# Altium schematic sheet peripherals.SchDoc(U_peripherals)
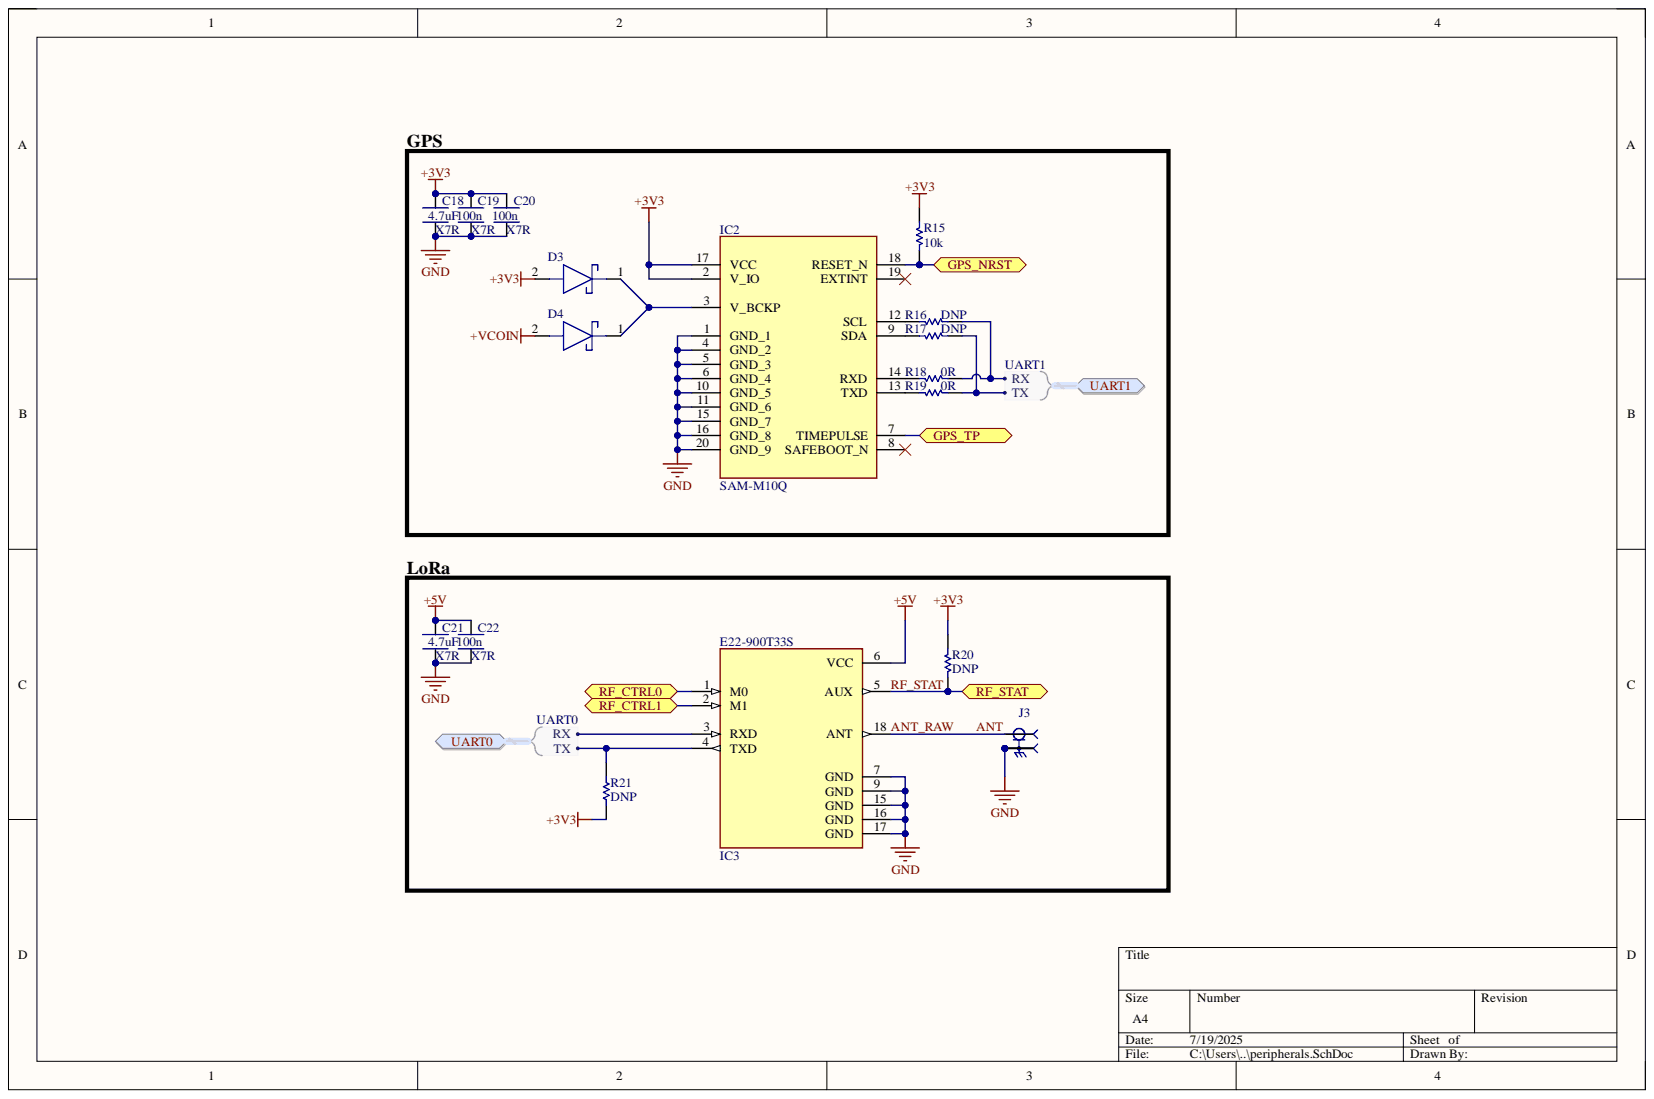
[diagram p0: peripherals.SchDoc]

## Parts
C18  4.7uF  Dielectric: X7R  [CAP_1206]
C19  100n  Dielectric: X7R  [CAP_0603]
C20  100n  Dielectric: X7R  [CAP_0603]
C21  4.7uF  Dielectric: X7R  [CAP_1206]
C22  100n  Dielectric: X7R  [CAP_0603]
D3  CUS10S30,H3F  [1SS357TPH3F]  (value not drawn)
D4  CUS10S30,H3F  [1SS357TPH3F]  (value not drawn)
IC2  SAM-M10Q  [SAMM10Q]
IC3  E22-900T33S  [E22-900T33S]
J3  CON-SMA-EDGE-S  [RFSOLUTIONS_CON-SMA-EDGE-S]  (value not drawn)
R15  10k  [RES_0603]
R16  DNP  [RES_0603]
R17  DNP  [RES_0603]
R18  0R  [RES_0603]
R19  0R  [RES_0603]
R20  DNP  [RES_0603]
R21  DNP  [RES_0603]

## Ports
GPS_NRST, GPS_TP, RF_CTRL0, RF_CTRL1, RF_STAT, UART0, UART0.RX, UART0.TX, UART1, UART1.RX, UART1.TX

## Nets
+3V3: C18.2 C19.2 C20.2 D3.2 IC2.2(V_IO) IC2.17(VCC) R15.1 R20.1 R21.1
+5V: C21.2 C22.2 IC3.6(VCC)
+VCOIN: D4.2
GND: C18.1 C19.1 C20.1 C21.1 C22.1 IC2.1(GND_1) IC2.4(GND_2) IC2.5(GND_3) IC2.6(GND_4) IC2.10(GND_5) IC2.11(GND_6) IC2.15(GND_7) IC2.16(GND_8) IC2.20(GND_9) IC3.7(GND) IC3.9(GND) IC3.15(GND) IC3.16(GND) IC3.17(GND) J3.G1 J3.G2 J3.G3 J3.G4
ANT: IC3.18(ANT) J3.1
GPS_NRST: IC2.18(RESET_N) R15.2 ; port GPS_NRST
GPS_TP: IC2.7(TIMEPULSE) ; port GPS_TP
RF_CTRL0: IC3.1(M0) ; port RF_CTRL0
RF_CTRL1: IC3.2(M1) ; port RF_CTRL1
RF_STAT: IC3.5(AUX) R20.2 ; port RF_STAT
NetD3_1: D3.1 D4.1 IC2.3(V_BCKP)
NetIC2_8: IC2.8(SAFEBOOT_N)
NetIC2_9: IC2.9(SDA) R17.1
NetIC2_12: IC2.12(SCL) R16.1
NetIC2_13: IC2.13(TXD) R19.1
NetIC2_14: IC2.14(RXD) R18.1
NetIC2_19: IC2.19(EXTINT)
NetIC3_3: IC3.3(RXD) ; port UART0
NetIC3_4: IC3.4(TXD) R21.2 ; port UART0
NetR16_2: R16.2 R18.2 ; port UART1
NetR17_2: R17.2 R19.2 ; port UART1

## Library data of the parts
C18 C21 = CAP_1206: Capacitor SMD 1206 generic; Component Kind: Standard; Library Name: myLib.SchLib; Value: 4.7uF
C19 C20 C22 = CAP_0603: Capacitor SMD 0603 generic; Component Kind: Standard; Library Name: myLib.SchLib; Value: 100n
D3 D4 = CUS10S30,H3F: Schottky Diode; Manufacturer_Name: Toshiba; Arrow Part Number: CUS10S30,H3F; Arrow Price/Stock: https://www.arrow.com/en/products/cus10s30h3f/toshiba?region=nac; Component Kind: Standard; Datasheet Link: http://toshiba.semicon-storage.com/info/docget.jsp?did=14077&prodName=CUS10S30; Height: 1mm; Library Name: SamacSys.SchLib; Manufacturer_Part_Number: CUS10S30,H3F; Mouser Part Number: 757-CUS10S30H3F; Mouser Price/Stock: https://www.mouser.co.uk/ProductDetail/Toshiba/CUS10S30H3F?qs=cW4DzVrAanO4Xm6ySrHr7g%3D%3D
IC2 = SAM-M10Q: Integrated Circuit; Manufacturer_Name: u-blox; Component Kind: Standard; Datasheet Link: https://content.u-blox.com/sites/default/files/documents/SAM-M10Q_IntegrationManual_UBX-22020019.pdf; Height: 6.8mm; Library Name: SamacSys.SchLib; Manufacturer_Part_Number: SAM-M10Q; Mouser Part Number: N/A; Mouser Price/Stock: https://www.mouser.co.uk/ProductDetail/u-blox/SAM-M10Q?qs=vvQtp7zwQdOcUykyQtAdbA%3D%3D
IC3 = E22-900T33S: based on SX1262; Component Kind: Standard; Library Name: myLib.SchLib
J3 = CMP-002-00169-1: SMA Connector Jack, Female Socket - Board Edge, End Launch Solder; MF: RF Solutions; Case/Package: None; Check_prices: https://www.snapeda.com/parts/CON-SMA-EDGE-S/RF+Solutions/view-part/?ref=eda; Component Kind: Standard; Component Type: Standard; Design Item ID: CON-SMA-EDGE-S; Digi-Key_Part_Number: CON-SMA-EDGE-S-ND; MP: CON-SMA-EDGE-S; Pins: 5; Purchase-URL: https://pricing.snapeda.com/search/part/CON-SMA-EDGE-S/?ref=eda; SnapEDA_Link: https://www.snapeda.com/parts/CON-SMA-EDGE-S/RF+Solutions/view-part/?ref=snap; Source: CON-SMA-EDGE-S.SchLib; Library Name: UTS Rocketry Workspace
R15-R21 = RES_0603: resistor SMD 0603 generic; Component Kind: Standard; Library Name: myLib.SchLib
  R15: value: 10k
  R16 R17 R20 R21: value: DNP
  R18 R19: value: 0R
